# Altium schematic sheet MainElectronics.SchDoc(MainElectronics)
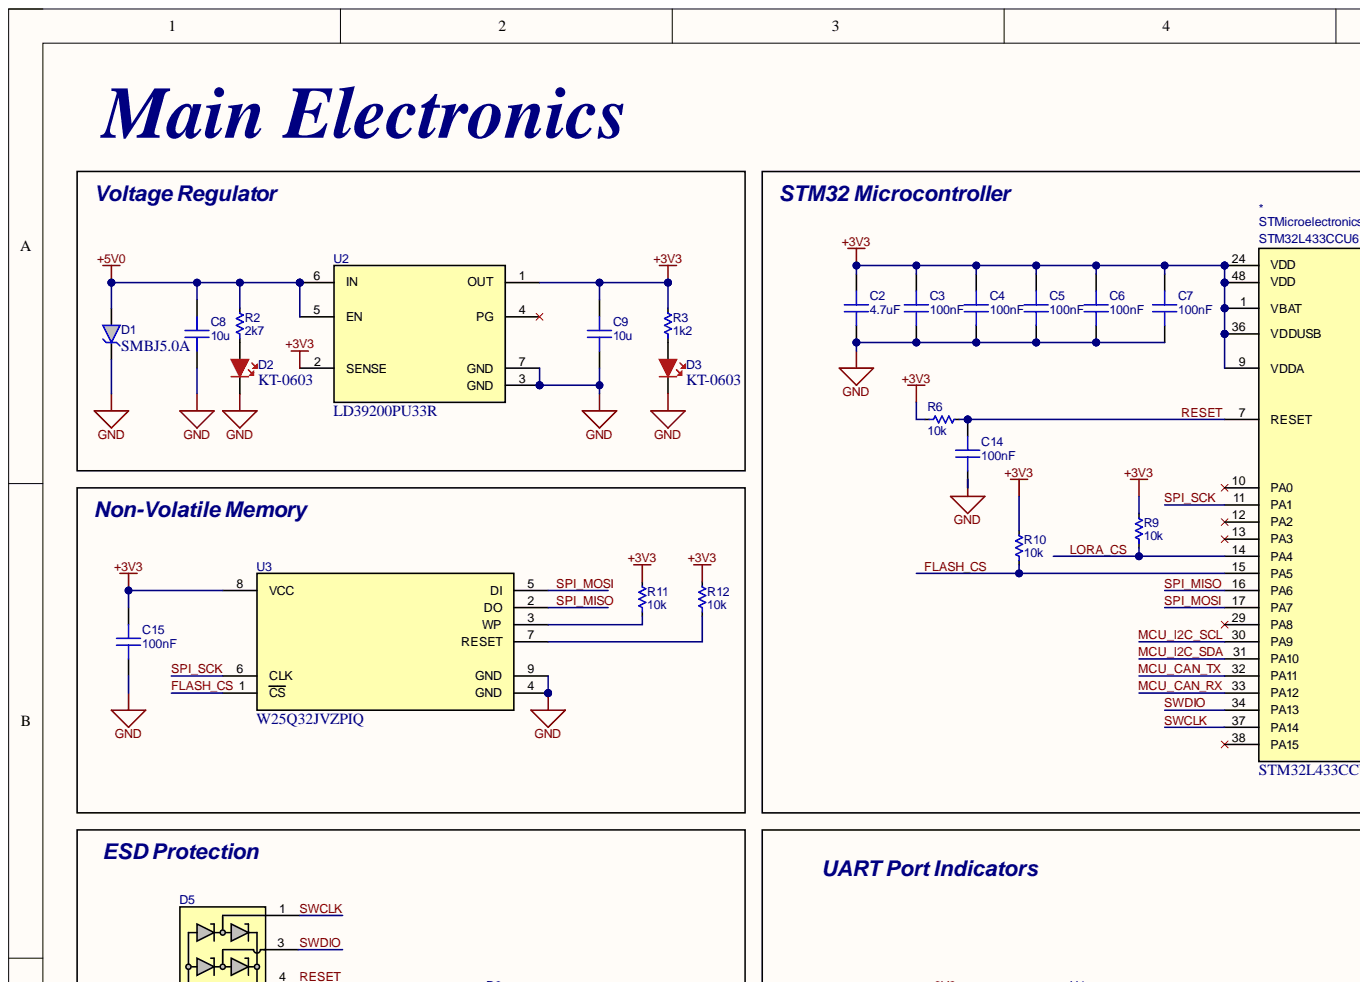
[diagram p0: MainElectronics.SchDoc, top left]
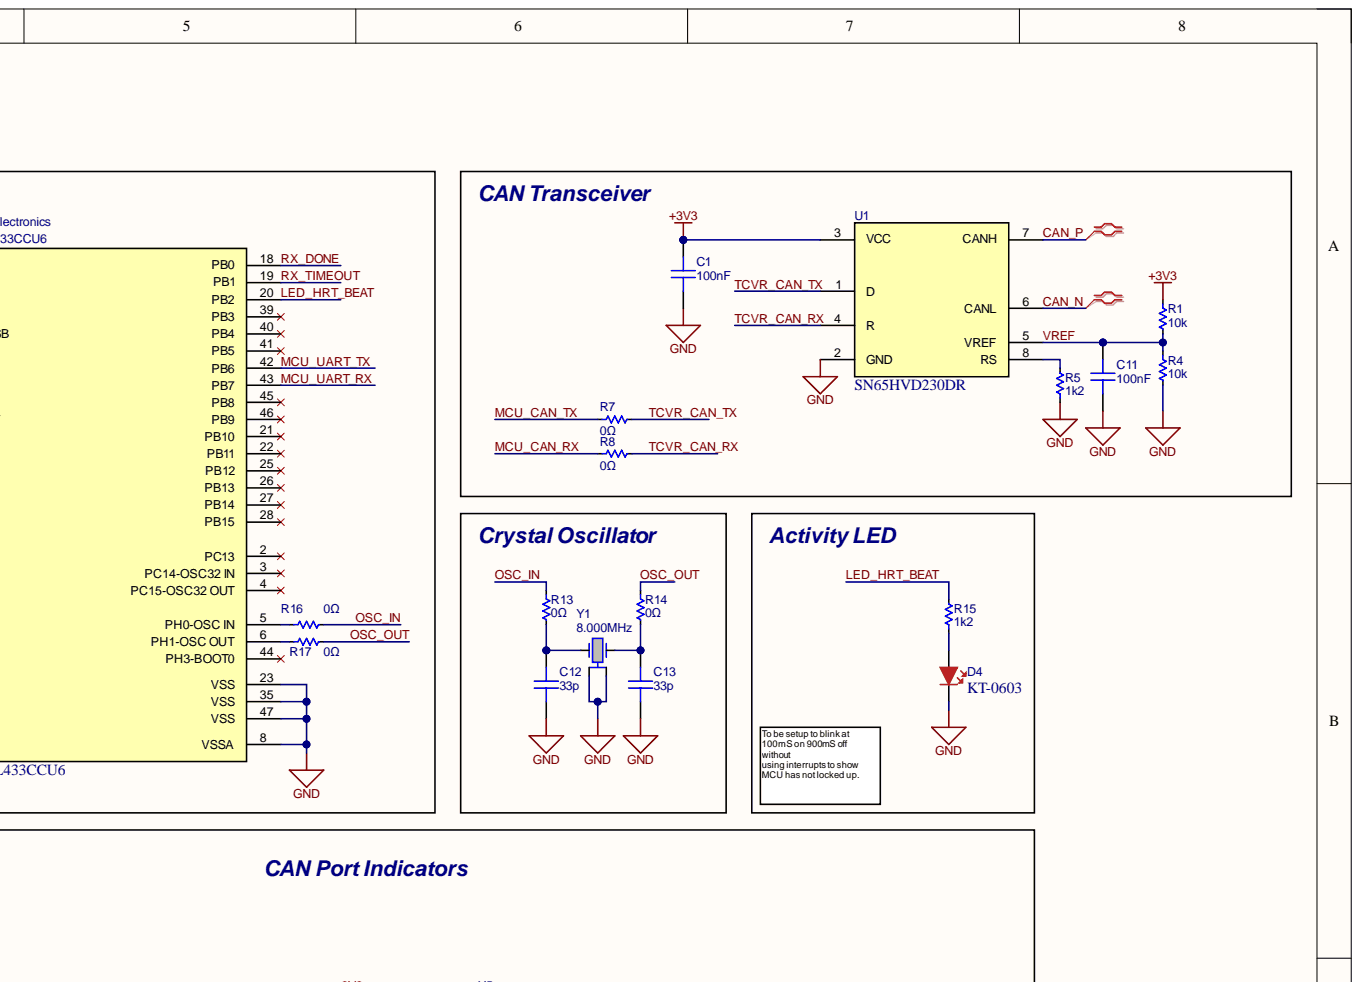
[diagram p1: MainElectronics.SchDoc, top right]
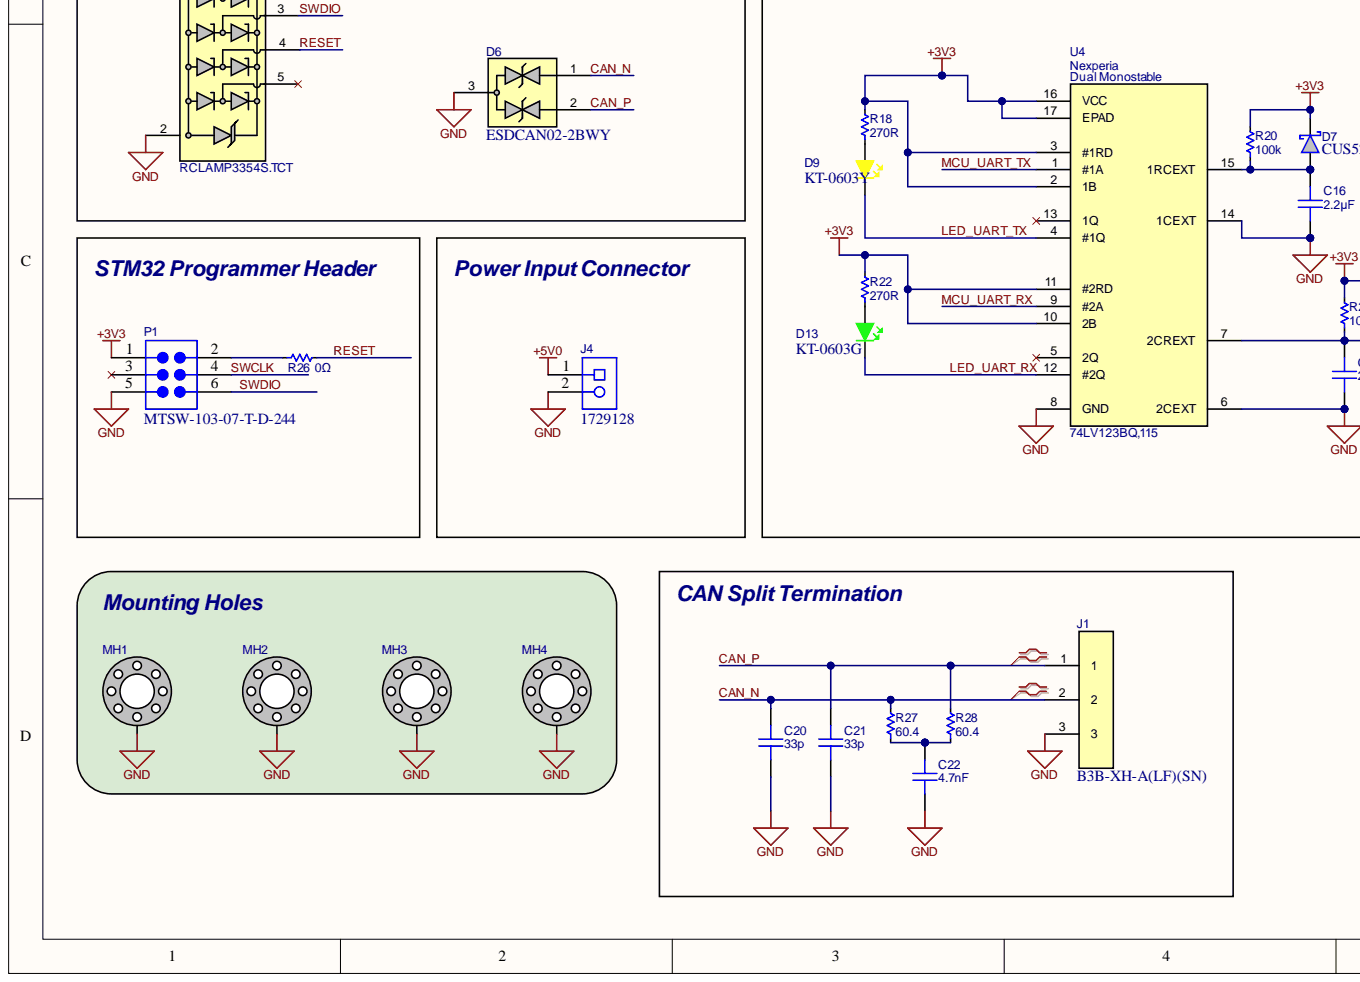
[diagram p2: MainElectronics.SchDoc, bottom left]
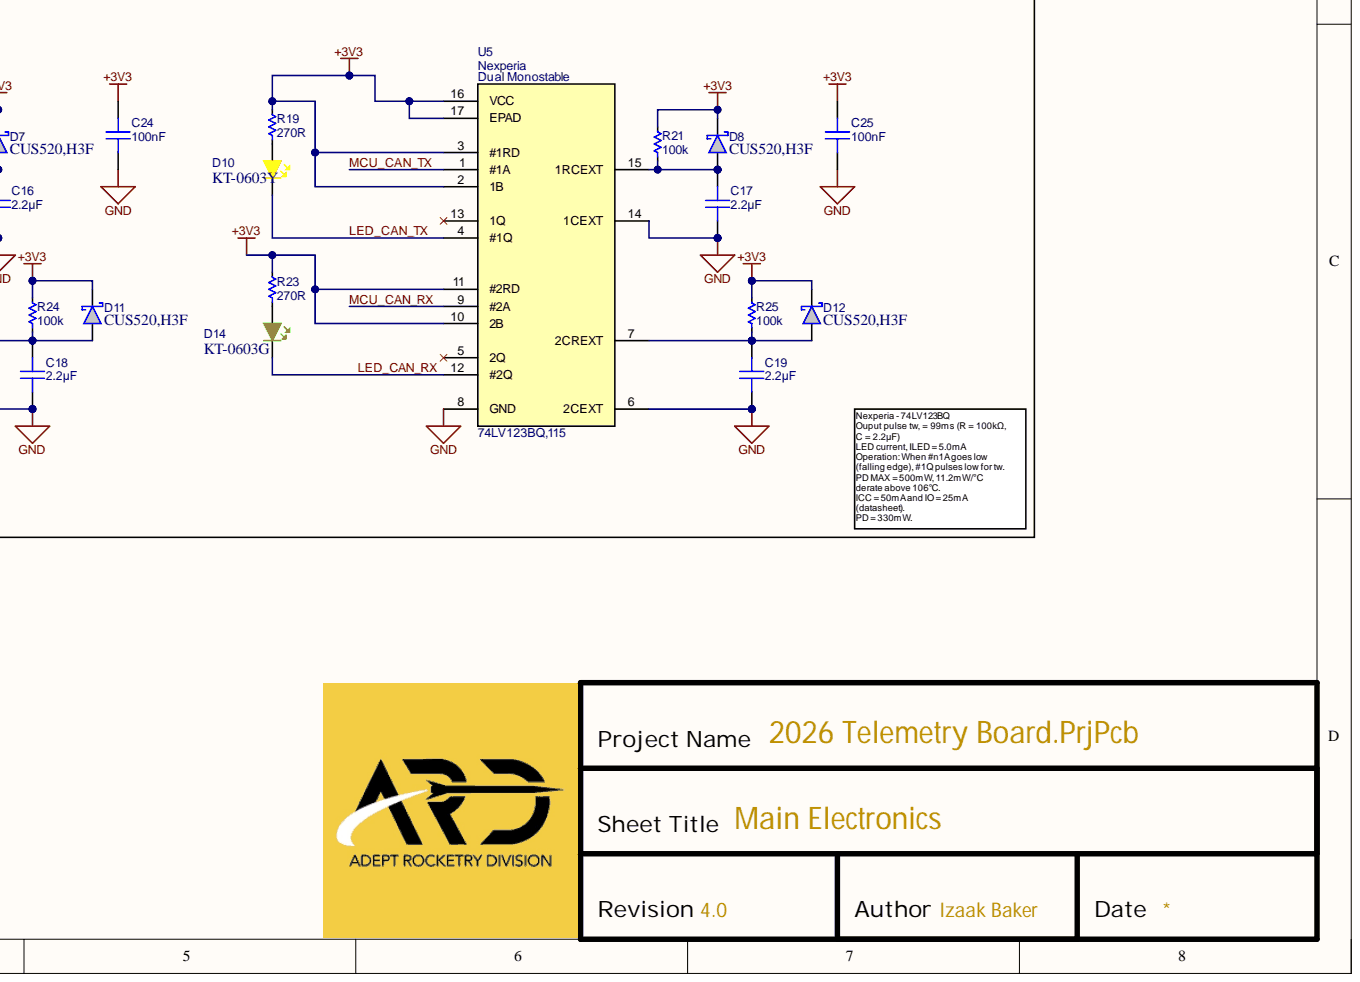
[diagram p3: MainElectronics.SchDoc, bottom right]

## Parts
*  STM32L433CCU6  [QFN50P700X700X60-49N]
C1  value 100nF  [CAP0402]  (hidden comment: C0402C104K4RACTU)
C2  value 4.7uF  [CAP0603]  (hidden comment: CGA0603X7R475K160JT)
C3  value 100nF  [CAP0402]  (hidden comment: C0402C104K4RACTU)
C4  value 100nF  [CAP0402]  (hidden comment: C0402C104K4RACTU)
C5  value 100nF  [CAP0402]  (hidden comment: C0402C104K4RACTU)
C6  value 100nF  [CAP0402]  (hidden comment: C0402C104K4RACTU)
C7  value 100nF  [CAP0402]  (hidden comment: C0402C104K4RACTU)
C8  value 10u  [CAP0603]  (hidden comment: CL10A106MA8NRNC)
C9  value 10u  [CAP0603]  (hidden comment: CL10A106MA8NRNC)
C11  value 100nF  [CAP0402]  (hidden comment: C0402C104K4RACTU)
C12  value 33p  [CAP0402]  (hidden comment: 0402CG330J500NT)
C13  value 33p  [CAP0402]  (hidden comment: 0402CG330J500NT)
C14  value 100nF  [CAP0402]  (hidden comment: C0402C104K4RACTU)
C15  value 100nF  [CAP0402]  (hidden comment: C0402C104K4RACTU)
C16  value 2.2µF  [FP-C0603C225K4PACTU-IPC_B]  (hidden comment: C0603C225K4PACTU)
C17  value 2.2µF  [FP-C0603C225K4PACTU-IPC_B]  (hidden comment: C0603C225K4PACTU)
C18  value 2.2µF  [FP-C0603C225K4PACTU-IPC_B]  (hidden comment: C0603C225K4PACTU)
C19  value 2.2µF  [FP-C0603C225K4PACTU-IPC_B]  (hidden comment: C0603C225K4PACTU)
C20  value 33p  [CAP0402]  (hidden comment: 0402CG330J500NT)
C21  value 33p  [CAP0402]  (hidden comment: 0402CG330J500NT)
C22  value 4.7nF  [CAP0603]  (hidden comment: 0603B472K500NT)
C24  value 100nF  [CAP0402]  (hidden comment: C0402C104K4RACTU)
C25  value 100nF  [CAP0402]  (hidden comment: C0402C104K4RACTU)
D1  SMBJ5.0A  [FP-SMBJ-MFG]
D2  KT-0603  [LED0603]
D3  KT-0603  [LED0603]
D4  KT-0603  [LED0603]
D5  RCLAMP3354S.TCT  [RCLAMP3354S.TCT-Footprint-1]
D6  ESDCAN02-2BWY  [FP-SOT323-3L-IPC_B]
D7  CUS520,H3F  [FP-USC-MFG]
D8  CUS520,H3F  [FP-USC-MFG]
D9  KT-0603Y  [LED0603]
D10  KT-0603Y  [LED0603]
D11  CUS520,H3F  [FP-USC-MFG]
D12  CUS520,H3F  [FP-USC-MFG]
D13  KT-0603G  [LED0603]
D14  KT-0603G  [LED0603]
J1  B3B-XH-A(LF)(SN)  [FP-B3B-XH-A_LF_SN-MFG]
J4  1729128  [PHOE-1729128-2_V]
MH1  MH_3.1_7.0_ViaRing  [MountingHole]  (value not drawn)
MH2  MH_3.1_7.0_ViaRing  [MountingHole]  (value not drawn)
MH3  MH_3.1_7.0_ViaRing  [MountingHole]  (value not drawn)
MH4  MH_3.1_7.0_ViaRing  [MountingHole]  (value not drawn)
P1  MTSW-103-07-T-D-244  [SMTC-MTSW-103-07-X-D-244]
R1  value 10k  [RES0603]  (hidden comment: 0603WAF1002T5E)
R2  value 2k7  [RES0603]  (hidden comment: 0603WAF2701T5E)
R3  value 1k2  [RES0603]  (hidden comment: 0603WAF1201T5E)
R4  value 10k  [RES0603]  (hidden comment: 0603WAF1002T5E)
R5  value 1k2  [RES0603]  (hidden comment: 0603WAF1201T5E)
R6  value 10k  [RES0603]  (hidden comment: 0603WAF1002T5E)
R7  value 0Ω  [RES0603]  (hidden comment: 0603WAF0000T5E)
R8  value 0Ω  [RES0603]  (hidden comment: 0603WAF0000T5E)
R9  value 10k  [RES0603]  (hidden comment: 0603WAF1002T5E)
R10  value 10k  [RES0603]  (hidden comment: 0603WAF1002T5E)
R11  value 10k  [RES0603]  (hidden comment: 0603WAF1002T5E)
R12  value 10k  [RES0603]  (hidden comment: 0603WAF1002T5E)
R13  value 0Ω  [RES0603]  (hidden comment: 0603WAF0000T5E)
R14  value 0Ω  [RES0603]  (hidden comment: 0603WAF0000T5E)
R15  value 1k2  [RES0603]  (hidden comment: 0603WAF1201T5E)
R16  value 0Ω  [RES0603]  (hidden comment: 0603WAF0000T5E)
R17  value 0Ω  [RES0603]  (hidden comment: 0603WAF0000T5E)
R18  value 270R  [RES0603]  (hidden comment: 0603WAF2700T5E)
R19  value 270R  [RES0603]  (hidden comment: 0603WAF2700T5E)
R20  value 100k  [RES0603]  (hidden comment: 0603WAF1003T5E)
R21  value 100k  [RES0603]  (hidden comment: 0603WAF1003T5E)
R22  value 270R  [RES0603]  (hidden comment: 0603WAF2700T5E)
R23  value 270R  [RES0603]  (hidden comment: 0603WAF2700T5E)
R24  value 100k  [RES0603]  (hidden comment: 0603WAF1003T5E)
R25  value 100k  [RES0603]  (hidden comment: 0603WAF1003T5E)
R26  value 0Ω  [RES0603]  (hidden comment: 0603WAF0000T5E)
R27  value 60.4  [RES0603]  (hidden comment: RC0603FR-0760R4L)
R28  value 60.4  [RES0603]  (hidden comment: RC0603FR-0760R4L)
U1  SN65HVD230DR  [FP-D0008A-IPC_B]
U2  LD39200PU33R  [FP-DFN6-7946637-MFG]
U3  W25Q32JVZPIQ  [FP-ZP-IPC_B]
U4  74LV123BQ,115  [DHVQFN16_SOT763-1_NEX]
U5  74LV123BQ,115  [DHVQFN16_SOT763-1_NEX]
Y1  XTAL_8.000MHz_18pF_50PPM_10PPM_100uW  [FP-ABM8AIG-MFG]  (value not drawn)

## Nets
+3V3: *.1(VBAT) *.9(VDDA) *.24(VDD) *.36(VDDUSB) *.48(VDD) C1.2 C2.2 C3.2 C4.2 C5.2 C6.2 C7.2 C9.2 C15.2 C24.2 C25.2 D7.2 D8.2 D11.2 D12.2 P1.1 R1.1 R3.2 R6.1 R9.2 R10.2 R11.2 R12.2 R18.2 R19.2 R20.2 R21.2 R22.2 R23.2 R24.2 R25.2 U1.3(VCC) U2.1(OUT) U2.2(SENSE) U3.8(VCC) U4.2(1B) U4.3(#1RD) U4.10(2B) U4.11(#2RD) U4.16(VCC) U4.17(EPAD) U5.2(1B) U5.3(#1RD) U5.10(2B) U5.11(#2RD) U5.16(VCC) U5.17(EPAD)
+5V0: C8.2 D1.1 J4.1 R2.2 U2.5(EN) U2.6(IN)
GND: *.8(VSSA) *.23(VSS) *.35(VSS) *.47(VSS) C1.1 C2.1 C3.1 C4.1 C5.1 C6.1 C7.1 C8.1 C9.1 C11.1 C12.1 C13.1 C14.1 C15.1 C16.1 C17.1 C18.1 C19.1 C20.1 C21.1 C22.1 C24.1 C25.1 D1.2 D2.K D3.K D4.K D5.2 D6.3 J1.3 J4.2 MH1.1 MH2.1 MH3.1 MH4.1 P1.5 R4.2 R5.1 U1.2(GND) U2.3(GND) U2.7(GND) U3.4(GND) U3.9(GND) U4.6(2CEXT) U4.8(GND) U4.14(1CEXT) U5.6(2CEXT) U5.8(GND) U5.14(1CEXT) Y1.2 Y1.4
CAN_N: C20.2 D6.1 J1.2 R27.2 U1.6(CANL)
CAN_P: C21.2 D6.2 J1.1 R28.2 U1.7(CANH)
FLASH_CS: *.15(PA5) R10.1 U3.1(CS)
LED_CAN_RX: D14.K U5.12(#2Q)
LED_CAN_TX: D10.K U5.4(#1Q)
LED_HRT_BEAT: *.20(PB2) R15.2
LED_UART_RX: D13.K U4.12(#2Q)
LED_UART_TX: D9.K U4.4(#1Q)
LORA_CS: *.14(PA4) R9.1
MCU_CAN_RX: *.33(PA12) R8.1 U5.9(#2A)
MCU_CAN_TX: *.32(PA11) R7.1 U5.1(#1A)
MCU_I2C_SCL: *.30(PA9)
MCU_I2C_SDA: *.31(PA10)
MCU_UART_RX: *.43(PB7) U4.9(#2A)
MCU_UART_TX: *.42(PB6) U4.1(#1A)
OSC_IN: R13.1 R16.2
OSC_OUT: R14.1 R17.2
RESET: *.7(RESET) C14.2 D5.4 R6.2 R26.2
RX_DONE: *.18(PB0)
RX_TIMEOUT: *.19(PB1)
SPI_MISO: *.16(PA6) U3.2(DO)
SPI_MOSI: *.17(PA7) U3.5(DI)
SPI_SCK: *.11(PA1) U3.6(CLK)
SWCLK: *.37(PA14) D5.1 P1.4
SWDIO: *.34(PA13) D5.3 P1.6
TCVR_CAN_RX: R8.2 U1.4(R)
TCVR_CAN_TX: R7.2 U1.1(D)
VREF: C11.2 R1.2 R4.1 U1.5(VREF)
Net*_5: *.5(PH0-OSC_IN) R16.1
Net*_6: *.6(PH1-OSC_OUT) R17.1
NetC12_2: C12.2 R13.2 Y1.1
NetC13_2: C13.2 R14.2 Y1.3
NetC16_2: C16.2 D7.1 R20.1 U4.15(1RCEXT)
NetC17_2: C17.2 D8.1 R21.1 U5.15(1RCEXT)
NetC18_2: C18.2 D11.1 R24.1 U4.7(2CREXT)
NetC19_2: C19.2 D12.1 R25.1 U5.7(2CREXT)
NetC22_2: C22.2 R27.1 R28.1
NetD2_A: D2.A R2.1
NetD3_A: D3.A R3.1
NetD4_A: D4.A R15.1
NetD9_A: D9.A R18.1
NetD10_A: D10.A R19.1
NetD13_A: D13.A R22.1
NetD14_A: D14.A R23.1
NetP1_2: P1.2 R26.1
NetR5_2: R5.2 U1.8(RS)
NetR11_1: R11.1 U3.3(WP)
NetR12_1: R12.1 U3.7(RESET)

Not connected: * 2(PC13) 3(PC14-OSC32_IN) 4(PC15-OSC32_OUT) 10(PA0) 12(PA2) 13(PA3) 21(PB10) 22(PB11) 25(PB12) 26(PB13) 27(PB14) 28(PB15) 29(PA8) 38(PA15) 39(PB3) 40(PB4) 41(PB5) 44(PH3-BOOT0) 45(PB8) 46(PB9); D5 5; P1 3; U2 4(PG); U4 5(2Q) 13(1Q); U5 5(2Q) 13(1Q)

## Library data of the parts
* = CMP-00137-8: Ultra-low-power with FPU ARM Cortex-M4 MCU 80 MHz with 256 Kbytes Flash, LCD, USB; ADC Channels (Resolution): 10-Ch 12-Bit; Clock Frequency: 48MHz; DAC Channels (Resolution): 2-Ch 12-Bit; Data Bus Width: 32b; EEPROM Memory Size: 0B; Flash Memory Size: 256kB; Frequency: 80MHz; Interface: CAN, QSPI, UART, USB; Max Junction Temperature (Tj): 105°C; Max Supply Voltage: 3.6V; Min Supply Voltage: 1.71V; Nominal Supply Current: 10nA; Number of A/D Converters: 1; Number of ADC Channels: 10; Number of D/A Converters: 2; Number of I2C Channels: 3; Number of I/Os: 38; Number of Timers/Counters: 9; Number of UART Channels: 4; Operating Supply Voltage: 1.8V; Peripherals: LCD, PWM; RAM Size: 64kB; Ambient Temperature Range High: 105°C; China RoHS: Compliant; Component Kind: Standard; Core Architecture: ARM Cortex-M4; Height: 650µm; Height - Seated (Max): 600µm; Lead Free: Lead Free; Length: 7mm; Lifecycle Status: Production; Max Operating Temperature: 85°C; Memory Format: FLASH; Memory Size: 256kB; Min Operating Temperature: -40°C; Number of SPI Channels: 3; Number of Terminals: 48; Number of USART Channels: 3; Number of USB Channels: 1; REACH SVHC: Yes; RoHS: Compliant; Schedule B: 8542310000, 8542310000; Temperature Grade: Industrial; Terminal Pitch: 500µm; Width: 7mm; Library Name: Adept Rocketry Division (ARD)
C1 C3-C7 C11 C14 C15 C24 C25 = CMP-001-00085-4: Ceramic Capacitors MLCC - SMD/SMT 16V 0.1uF X7R 0402 10%; Case/Package: 0402; Component Kind: Standard; Max Operating Temperature: 125°C; Min Operating Temperature: -55°C; Mounting Technology: Surface Mount; Pins: 2; Tolerance: 10%; Voltage Rating: 16V; Library Name: Adept Rocketry Division (ARD)
C2 = CMP-00136-3: 16V 4.7uF X7R ±10% 0603 Multilayer Ceramic Capacitors MLCC - SMD/SMT ROHS; Manufacturer: HRE; Component Kind: Standard; LCSC Part #: C22399629; Manufacturer PN: CGA0603X7R475K160JT; Package: 0603; Supplier: JLPCB; Supplier PN: C22399629; Voltage - Rated: 16V; Library Name: Adept Rocketry Division (ARD)
C8 C9 = CMP-00128-4: CAP CER 10UF 25V X5R 0603; Manufacturer: Samsung Electro-Mechanics; Tolerance: 20%; Component Kind: Standard; LCSC Part #: C96446; Manufacturer PN: CL10A106MA8NRNC; Max Operating Temperature: 85°C; Min Operating Temperature: -55°C; Package: 0603; Supplier: JLPCB; Supplier PN: C96446; Temperature Coefficient: X5R; Voltage - Rated: 25V; Library Name: Adept Rocketry Division (ARD)
C12 C13 C20 C21 = CMP-00078-2: 33pF 50V C0G ±5% 0402 Multilayer Ceramic Capacitors MLCC; Manufacturer: FH; Component Kind: Standard; LCSC Part #: C1562; Manufacturer PN: 0402CG330J500NT; Max Operating Temperature: 125°C; Min Operating Temperature: -55°C; Package: 0402; Supplier: LCSC; Supplier PN: C1562; Temperature Coefficient: C0G; Tolerance: 5%; Voltage - Rated: 50V; Library Name: Adept Rocketry Division (ARD)
C16-C19 = CMP-001-00104-1: 2.2 µF ±10% 16V Ceramic Capacitor X5R 0603 (1608 Metric); Case/Package: 0603; Component Kind: Standard; JLCPCB Part #: C7463167; Max Operating Temperature: 85°C; MFR Part #: C0603C225K4PACTU; Min Operating Temperature: -55°C; Mounting Technology: Surface Mount; Pins: 2; Tolerance: 10%; Voltage Rating: 16V; Library Name: Adept Rocketry Division (ARD)
C22 = CMP-00018-3: 50V 4.7nF X7R ±10% 0603 Multilayer Ceramic Capacitors MLCC - SMD/SMT ROHS; Manufacturer: Guangdong Fenghua Advanced Tech; Component Kind: Standard; LCSC Part #: C53987; Manufacturer PN: 0603B472K500NT; Package: 0603; Supplier: JLCPCB; Supplier PN: C53987; Temperature Coefficient: X7R; Tolerance: 10%; Voltage - Rated: 50V; Library Name: Adept Rocketry Division (ARD)
D1 = CMP-00149-1: DO-214AA SMB SMBJ 1 Surface Mount Zener Tvs Diode 6.4V 800muA 600W -55C~150C TJ; Breakdown Voltage: 6.7V; Clamping Voltage: 9.2V; Current: 68A; Direction: Unidirectional; Element Configuration: Single; ESD Protection: Yes; Forward Voltage: 3.5V; Leakage Current: 800µA; Max Breakdown Voltage: 7V; Max Junction Temperature (Tj): 150°C; Max Power Dissipation: 5W; Max Surge Current: 65.3A; Number of Channels: 1; Operating Supply Voltage: 5V; Power Line Protection: No; Test Current: 10mA; Case/Package: SMB; China RoHS: Non-Compliant; Component Kind: Standard; Composition: Zener; Country of Origin: Mainland China, Philippines; Export Control Classification Number (ECCN) Code: EAR99; Harmonized Tariff Schedule (HTS) Code: 8541.10.00.50; Height: 2.61mm; Introduction Date: 1996-01-01; Lead Free: Lead Free; Length: 4.5466mm; Lifecycle Status: Production; Manufacturer PN: SMBJ5.0A; Max Operating Temperature: 150°C; Max Reverse Leakage Current: 800µA; Min Breakdown Voltage: 6.4V; Min Operating Temperature: -65°C; Mounting Technology: Surface Mount; Number of Elements: 1; Number of Terminals: 2; Number of Unidirectional Channels: 1; Packaging: Cut Tape; Peak Pulse Current: 65.3A; Peak Pulse Power: 600W; Peak Reverse Current: 800µA; Pins: 2; Polarity: Unidirectional; Power Dissipation: 600W; Power Rating: 600W; Radiation Hardening: No; REACH SVHC: No; Reverse Breakdown Voltage: 6.4V; Reverse Standoff Voltage: 5V; Reverse Voltage: 5V; RoHS: Compliant; Schedule B: 8541100080, 8541100080; Supplier PN: C10204; Termination: SMD/SMT; Voltage: 5V; Voltage Rating (DC): 5V; Width: 3.937mm; Library Name: Adept Rocketry Division (ARD)
D2-D4 = CMP-00083-2: -40℃~+85℃ Red 0603 LED Indication - Discrete ROHS; Manufacturer: Hubei KENTO Elec; Manufacturer PN: KT-0603R; Colour: RED; Component Kind: Standard; LCSC Part #: C2286; Package: 0603; Supplier: LCSC; Supplier PN: C2286; Library Name: Adept Rocketry Division (ARD)
D5 = CMP-00154-1: RCLAMP3354S Series 3.3 V 5 pF SMT Surge Protection TVS Diode - SOT-23-5; Clamping Voltage: 16V; Min Breakdown Voltage: 3.5V; Number of Bidirectional Channels: 4; Number of Elements: 1; Peak Pulse Current: 25A; Peak Pulse Power: 400W; Polarity: Unidirectional; Power Line Protection: Yes; Reverse Standoff Voltage: 3.3V; Case/Package: SOT-23-5; China RoHS: Compliant; Component Kind: Standard; Contact Plating: Tin; Country of Origin: Mainland China; Export Control Classification Number (ECCN) Code: EAR99; Harmonized Tariff Schedule (HTS) Code: 8541.10.00.50; Introduction Date: 2010-04-19; Lead Free: Lead Free; Lifecycle Status: Production; Manufacturer PN: RCLAMP3354S.TCT; Max Operating Temperature: 85°C; Min Operating Temperature: -55°C; Mounting Technology: Surface Mount; Number of Terminals: 5; Packaging: Tape & Reel (TR); REACH SVHC: Yes; RoHS: Compliant; Schedule B: 8536300000, 8541100050, 8541100050; Supplier PN: C441850; Library Name: Adept Rocketry Division (ARD)
D6 = CMP-00153-1: TVS DIODE 26.5VWM 44VC SOT323-3 / 44V Clamp 3A (8/20µs) Ipp Tvs Diode Surface Mount SOT-323-3; Breakdown Voltage: 30.1V; Direction: Bidirectional; Max Breakdown Voltage: 31.7V; Max Junction Temperature (Tj): 175°C; Min Breakdown Voltage: 28.5V; Number of Bidirectional Channels: 2; Peak Pulse Power: 250W; Peak Reverse Current: 10nA; Power Line Protection: No; Reverse Standoff Voltage: 26.5V; Value: 3pF; Case/Package: SOT-323-3; China RoHS: Compliant; Clamping Voltage: 44V; Component Kind: Standard; Composition: Zener; Height: 1.1mm; Lifecycle Status: Production; Manufacturer PN: ESDCAN02-2BWY; Max Operating Temperature: 175°C; Min Operating Temperature: -55°C; Mounting Technology: Surface Mount; Number of Channels: 2; Number of Elements: 2; Number of Terminals: 3; Packaging: Tape and Reel; Peak Pulse Current: 3A; Polarity: Bidirectional; Ratings: AEC-Q101; REACH SVHC: Yes; RoHS: Compliant; Schedule B: 8536300000, 8536300000; Supplier PN: C2969759; Library Name: Adept Rocketry Division (ARD)
D7 D8 D11 D12 = CMP-00152-1: Rectifier Diode, Schottky, 1 Phase, 1 Element, 0.2A, 30V V(RRM), Silicon; Manufacturer PN: CUS520.H3F; Average Rectified Current: 200mA; Element Configuration: Single; Max Forward Surge Current (Ifsm): 1A; Max Junction Temperature (Tj): 125°C; Max Power Dissipation: 150mW; Number of Elements: 1; Peak Reverse Current: 5µA; Value: 17pF; Component Kind: Standard; Contact Plating: Silver, Tin; Forward Current: 200mA; Height: 1.15mm; Lifecycle Status: Production; Max Operating Temperature: 100°C; Max Output current: 200mA; Max Repetitive Reverse Voltage (Vrrm): 30V; Max Reverse Voltage (DC): 30V; Min Operating Temperature: -40°C; Mounting Technology: Surface Mount; Number of Terminals: 2; Packaging: Tape & Reel (TR); Peak Non-Repetitive Surge Current: 1A; Pins: 2; REACH SVHC: Yes; Reverse Standoff Voltage: 30V; Reverse Voltage: 30V; RoHS: Compliant; Schedule B: 8541100070, 8541100070; Supplier PN: C324473; Library Name: Adept Rocketry Division (ARD)
D9 D10 = CMP-007-00037-2: -40℃~+85℃ Yellow 0603 LED Indication - Discrete ROHS; Manufacturer: Hubei KENTO Elec; Colour: Yellow; Component Kind: Standard; Manufacturer PN: KT-0603Y; Package: 0603; Supplier: LCSC; Supplier PN: C2287; Library Name: Adept Rocketry Division (ARD)
D13 D14 = CMP-007-00036-1: -40℃~+85℃ Green 0603 LED Indication - Discrete ROHS; Manufacturer: Hubei KENTO Elec; Colour: RED; Component Kind: Standard; Manufacturer PN: KT-0603G; Package: 0603; Supplier: LCSC; Supplier PN: C12624; Library Name: Adept Rocketry Division (ARD)
J1 = CMP-00056-2: Connector Header, XH Series, 3 Position, 2.50mm, Through Hole, Press-Fit, Solder, Natural; Manufacturer: JST; Component Kind: Standard; Manufacturer_PN: B3B-XH-A(LF)(SN); Supplier: JLPCB; Supplier_PN: C144394; Library Name: Adept Rocketry Division (ARD)
J4 = CMP-2000-05815-1: PCB Terminal Block, 13.5 A, 400 V, 2-Pin THD, RoHS, Bulk; Manufacturer: Phoenix Contact; Current Rating: 13500mA; No. of Positions: 2; No. of Rows: 1; Package Version: 03/2015; Pitch: 5.08mm; Voltage Rating: 400V; Component Kind: Standard; Datasheet URL: https://datasheet.ciiva.com/16046/1729128-16046181.pdf; Datasheet Version: 12/2013; Library Name: Altium Content Vault; Manufacturer URL: http://www.phoenixcontact.com/; Mounting Technology: ThroughHole; Orientation: Horizontal; Package Description: 2-Pin Through Hole Device, Body 8.1 x 10.16 mm, Pitch 5.08 mm; Package Reference: 1729128-2; Package URL: https://www.phoenixcontact.com/online/portal/pi/pxc/product_detail_page/!ut/p/b1/3VdJjqNKFDxLH8AFycySyWY2ozFsEPOMMQaDOX1XedGL_1Vdm5YXJleknhSKeBkvI6EA8ncAo3AYoDhBQGco6KN7VURTdemj9us_IEKEZo_YHiPAEWEFmDGOHMORkqKYCORBZxgL7ZoaZYcbFFUZ3fqxSY6GOuxydWUuHqz1ynmMFygu583Bob0Ewr6Sd_l-5m-kAueHiB0jpRwZwe58wwtkpxtMYd_LmGcDRU02dRNEyWt6Y2ti5pSyJ_reIkzIulrqApdnbT11V5jnCKbYNCvhEM1MCpPByat_X379-iThf08CI5GfOPqfBeS3BRoBOdD55AKVv0iLwCMq-2BrzXWSGRH7pUGD9uwXQjiph3xL0DKQwdGa0JImiIOmBNOestqgwTHSSs2oOep-78apyXQBvNi8ZLaFjvjWvcaUEBu0MC6Wbe-d6mB0FAebo2lUWinqYaZs5DAYzV0RP9yitUjnGJ0iI9xhZkT42OCQNUJJhkgWINNQLP2JFP6OpMg3JCVg70jqHT0lvKOnhHf0lPuOnnLf0VPuWx6_dxwUIvwk5WMkT0kLL1mh61l2yZVSzG3pYV_bqo-mj6uMKwKwdnnW8Jh5RTOJzMOA5c-eJDaZQw4mTMPH02PvS_ZAqNVjVTo2WyYLkwH_KU1mmmG5kjRhDqPXLwwVHvVOjCM60-fpXvTDfMGW1PVFVS1r4e4yVDk4ZdNbkUHvqPqQSeian4UD39fNKmachqdJ1NJbyk8rXT9mXTvPk3oE5meulKGgiruPJek-4I9hTT6G8ZLOyRSm2RRVbThERQad5OS28hdt4YW4vG0stgYYzsWsK4_7y6DZMjJSCqri1kyiZR5vD7pwIrNR7QwPHTcLTI5qT7eRlpHrdGiupYlqQDJ7zy_CND8fWWBoLRXxk1RVcXiBQwbTD4Xi7KL-FuO94t9xaqweXzH; Packing: Bulk; PartNumber: 1729128; RoHS: TRUE
MH1-MH4 = CMP-00028-00007-1: Mounting Hole, 3.1mm Hole Size, 7.0mm Annulus, with Via Ring; Component Kind: Standard; Library Name: Adept Rocketry Division (ARD)
P1 = CMP-1024-00097-1: Modified .025" SQ Post Header, Through-hole, Vertical, -55 to 105 degC, 2.54 mm Pitch, 6-Pin, Male, RoHS; Manufacturer: Samtec; Pitch: 2.54 mm; Component Kind: Standard; ComponentLink1Description: Manufacturer URL; ComponentLink1URL: http://www.samtec.com; ComponentLink2Description: Datasheet; ComponentLink2URL: http://www.samtec.com/documents/webfiles/pdf/MTSW.PDF; ComponentLink3Description: Package Specification; ComponentLink3URL: http://www.samtec.com/documents/webfiles/cpdf/MTSW-XXX-XX-X-X-XXXX-XX-XXX-MKT1.pdf; DatasheetVersion: F-214; Library Name: Altium Content Vault; Mounting Technology: Through-hole; Orientation: Vertical; PackageDescription: Assembly .025" [.64] SQ Post Terminal Strip; PackageReference: MTSW-103-07-X-D-244; PackageVersion: BI, 2/1987; PartNumber: MTSW-103-07-T-D-244; RoHS: True; Temperature Range: -55 to 105 degC
R1 R4 R6 R9-R12 = CMP-00069-4: 100mW Thick Film Resistors 75V ±100ppm/℃ ±1% 10kΩ 0603 Chip Resistor - Surface Mount ROHS; Manufacturer: UNI-ROYAL; Component Kind: Standard; LCSC Part #: C25804; Manufacturer PN: 0603WAF1002T5E; Max Operating Temperature: 155°C; Min Operating Temperature: -55°C; Package: 0603; Power: 100mW; Supplier: LCSC; Supplier PN: C25804; Tolerance: 1%; Library Name: Adept Rocketry Division (ARD)
R2 = CMP-00100-3: 100mW Thick Film Resistors 75V ±100ppm/℃ ±1% 2.7kΩ 0603 Chip Resistor - Surface Mount ROHS; Manufacturer: UNI-ROYAL; Component Kind: Standard; LCSC Part #: C13167; Manufacturer PN: 0603WAF2701T5E; Max Operating Temperature: 155°C; Min Operating Temperature: -55°C; Package: 0603; Power: 100mW; Supplier: LCSC; Supplier PN: C13167; Tolerance: 1%; Library Name: Adept Rocketry Division (ARD)
R3 R5 R15 = CMP-00087-3: 100mW Thick Film Resistors 75V ±100ppm/℃ ±1% 1.2kΩ 0603 Chip Resistor - Surface Mount ROHS; Manufacturer: UNI-ROYAL; Component Kind: Standard; LCSC Part #: C22765; Manufacturer PN: 0603WAF1201T5E; Max Operating Temperature: 155°C; Min Operating Temperature: -55°C; Package: 0603; Power: 100mW; Supplier: LCSC; Supplier PN: C22765; Tolerance: 1%; Library Name: Adept Rocketry Division (ARD)
R7 R8 R13 R14 R16 R17 R26 = CMP-RES-00000-2: JUMPER 100mW Thick Film Resistors 75V ±100ppm/℃ ±1% 10kΩ 0603 Chip Resistor - Surface Mount ROHS; Manufacturer: UNI-ROYAL; Component Kind: Standard; LCSC Part #: C25804; Manufacturer PN: 0603WAF0000T5E; Max Operating Temperature: 155°C; Min Operating Temperature: -55°C; Package: 0603; Power: 100mW; Supplier: LCSC; Supplier PN: C21189; Tolerance: 1%; Library Name: Adept Rocketry Division (ARD)
R18 R19 R22 R23 = CMP-00101-3: 100mW Thick Film Resistors 75V ±100ppm/℃ ±1% 270Ω 0603 Chip Resistor - Surface Mount ROHS; Manufacturer: UNI-ROYAL; Component Kind: Standard; LCSC Part #: C22966; Manufacturer PN: 0603WAF2700T5E; Max Operating Temperature: 155°C; Min Operating Temperature: -55°C; Package: 0603; Power: 100mW; Supplier: LCSC; Supplier PN: C22966; Tolerance: 1%; Library Name: Adept Rocketry Division (ARD)
R20 R21 R24 R25 = CMP-00098-3: 100mW Thick Film Resistors 75V ±100ppm/℃ ±1% 100kΩ 0603 Chip Resistor - Surface Mount ROHS; Manufacturer: UNI-ROYAL; Component Kind: Standard; LCSC Part #: C25803; Manufacturer PN: 0603WAF1003T5E; Max Operating Temperature: 155°C; Min Operating Temperature: -55°C; Package: 0603; Power: 100mW; Supplier: LCSC; Supplier PN: C25803; Tolerance: 1%; Library Name: Adept Rocketry Division (ARD)
R27 R28 = CMP-00105-3: 100mW Thick Film Resistors 75V ±100ppm/℃ ±1% 60.4Ω 0603 Chip Resistor - Surface Mount ROHS; Manufacturer: YAGEO; Component Kind: Standard; LCSC Part #: C245987; Manufacturer PN: RC0603FR-0760R4L; Supplier: JLPCB; Supplier PN: C245987; Library Name: Adept Rocketry Division (ARD)
U1 = CMP-015-00008-3: 3.3 V CAN Transceiver with Standby Mode 8-SOIC -40 to 85; Max Supply Voltage: 3.6V; Min Supply Voltage: 3V; Case/Package: SOIC; Component Kind: Standard; Max Operating Temperature: 85°C; Min Operating Temperature: -40°C; Pins: 8; Library Name: Adept Rocketry Division (ARD)
U2 = CMP-019-00055-1: 2 A high PSRR utra low drop linear regulator with reverse current protection; Dropout Voltage: 130mV; Max Supply Voltage: 6V; Min Supply Voltage: 1.25V; Output Current: 2A; Output Voltage: 3.3V; Case/Package: DFN; Component Kind: Standard; Max Operating Temperature: 125°C; Min Operating Temperature: -40°C; Mounting Technology: Surface Mount; Library Name: Adept Rocketry Division (ARD)
U3 = CMP-019-00056-2: NOR Flash Serial (SPI, Dual SPI, Quad SPI) 3V/3.3V 32M-bit 4M x 8 6ns 8-Pin WSON EP Tube; Clock Frequency: 133MHz; Max Supply Voltage: 3.6V; Memory Size: 4MB; Min Supply Voltage: 2.7V; Component Kind: Standard; Frequency: 133MHz; Max Operating Temperature: 85°C; Memory Format: FLASH; Min Operating Temperature: -40°C; Library Name: Adept Rocketry Division (ARD)
U4 U5 = CMP-016-00010-1: Multivibrator, Retriggerable Monostable, 74LV123, 46 ns, 12 mA, 1 V to 5.5 V, 16 Pins, DHVQFN; Max Supply Voltage: 5.5V; Min Supply Voltage: 1V; Component Kind: Standard; Max Operating Temperature: 125°C; Min Operating Temperature: -40°C; Mounting Technology: Surface Mount; Pins: 16; Library Name: Adept Rocketry Division (ARD)
Y1 = CMP-00150-1: Crystal, Standard, 13.56 MHz, SMD, 3.2mm x 2.5mm x 0.8mm, 50 ppm, 12 pF, 20 ppm, ABM8AIG; Manufacturer PN: ABM8AIG-8.000MHZ-1Z-T; ESR: 500; ESR (Equivalent Series Resistance): 500Ω; Frequency: 8MHz; Frequency Stability: 50ppm; Frequency Tolerance: 0.002%; Load Capacitance: 18pF; Case/Package: SMD/SMT; China RoHS: Compliant; Component Kind: Standard; Country of Origin: Taiwan; Height: 800µm; Introduction Date: 2015-12-15; Length: 3.2mm; Lifecycle Status: Production; Max Operating Temperature: 125°C; Min Operating Temperature: -40°C; Mounting Technology: Surface Mount; Ratings: AEC-Q200; REACH SVHC: Yes; RoHS: Compliant; Supplier PN: C5140594; Tolerance: 0.002%; Width: 2.5mm; Library Name: Adept Rocketry Division (ARD)
